# Altium schematic sheet Power_Supply.SchDoc
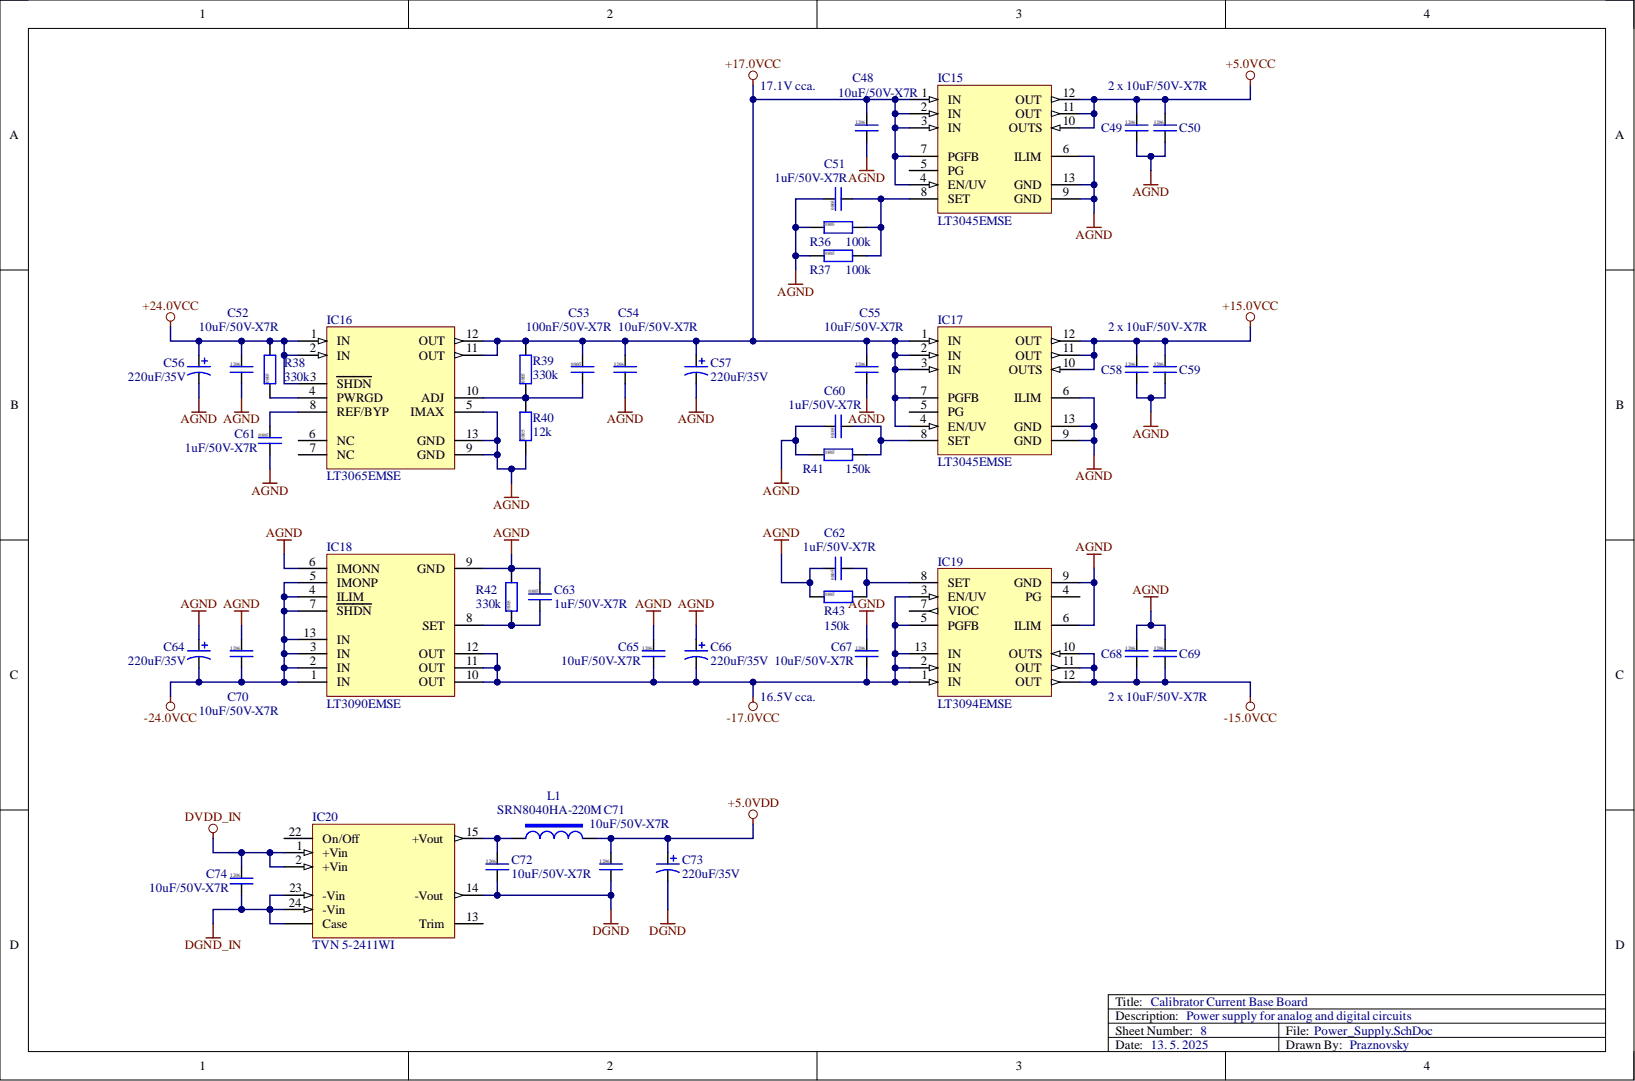
[diagram p0: Power_Supply.SchDoc]

## Parts
C48  10uF/50V-X7R  [C1206]
C49  10uF/50V-X7R  [C1206]
C50  10uF/50V-X7R  [C1206]
C51  1uF/50V-X7R  [C0805]
C52  10uF/50V-X7R  [C1206]
C53  100nF/50V-X7R  [C0805]
C54  10uF/50V-X7R  [C1206]
C55  10uF/50V-X7R  [C1206]
C56  220uF/35V  [ELCO 8.0mm x 10.2mm]
C57  220uF/35V  [ELCO 8.0mm x 10.2mm]
C58  10uF/50V-X7R  [C1206]
C59  10uF/50V-X7R  [C1206]
C60  1uF/50V-X7R  [C0805]
C61  1uF/50V-X7R  [C0805]
C62  1uF/50V-X7R  [C0805]
C63  1uF/50V-X7R  [C0805]
C64  220uF/35V  [ELCO 8.0mm x 10.2mm]
C65  10uF/50V-X7R  [C1206]
C66  220uF/35V  [ELCO 8.0mm x 10.2mm]
C67  10uF/50V-X7R  [C1206]
C68  10uF/50V-X7R  [C1206]
C69  10uF/50V-X7R  [C1206]
C70  10uF/50V-X7R  [C1206]
C71  10uF/50V-X7R  [C1206]
C72  10uF/50V-X7R  [C1206]
C73  220uF/35V  [ELCO 8.0mm x 10.2mm]
C74  10uF/50V-X7R  [C1206]
IC15  LT3045EMSE  [MSOP-12-EP]
IC16  LT3065EMSE  [MSOP-12-EP]
IC17  LT3045EMSE  [MSOP-12-EP]
IC18  LT3090EMSE  [MSOP-12-EP]
IC19  LT3094EMSE  [MSOP-12-EP]
IC20  TVN 5-2411WI  [TVN 5WI]
L1  SRN8040HA-220M  [SRN8040]
R36  100k  [R0805]
R37  100k  [R0805]
R38  330k  [R0805]
R39  330k  [R0805]
R40  12k  [R0805]
R41  150k  [R0805]
R42  330k  [R0805]
R43  150k  [R0805]

## Nets
+5.0VCC: C49.1 C50.1 IC15.10(OUTS) IC15.11(OUT) IC15.12(OUT)
+5.0VDD: C71.1 C73.1 L1.2
+15.0VCC: C58.1 C59.1 IC17.10(OUTS) IC17.11(OUT) IC17.12(OUT)
+17.0VCC: C48.1 C53.1 C54.1 C55.1 C57.1 IC15.1(IN) IC15.2(IN) IC15.3(IN) IC15.4(EN/UV) IC15.7(PGFB) IC16.11(OUT) IC16.12(OUT) IC17.1(IN) IC17.2(IN) IC17.3(IN) IC17.4(EN/UV) IC17.7(PGFB) R39.2
+24.0VCC: C52.1 C56.1 IC16.1(IN) IC16.2(IN) IC16.3(SHDN) R38.2
-15.0VCC: C68.2 C69.2 IC19.10(OUTS) IC19.11(OUT) IC19.12(OUT)
-17.0VCC: C65.2 C66.2 C67.2 IC18.10(OUT) IC18.11(OUT) IC18.12(OUT) IC19.1(IN) IC19.2(IN) IC19.3(EN/UV) IC19.5(PGFB) IC19.13(IN)
-24.0VCC: C64.2 C70.2 IC18.1(IN) IC18.2(IN) IC18.3(IN) IC18.4(ILIM) IC18.5(IMONP) IC18.7(SHDN) IC18.13(IN)
AGND: C48.2 C49.2 C50.2 C51.1 C52.2 C54.2 C55.2 C56.2 C57.2 C58.2 C59.2 C60.1 C61.2 C62.1 C63.1 C64.1 C65.1 C66.1 C67.1 C68.1 C69.1 C70.1 IC15.6(ILIM) IC15.9(GND) IC15.13(GND) IC16.5(IMAX) IC16.9(GND) IC16.13(GND) IC17.6(ILIM) IC17.9(GND) IC17.13(GND) IC18.6(IMONN) IC18.9(GND) IC19.6(ILIM) IC19.9(GND) R36.1 R37.1 R40.1 R41.1 R42.2 R43.1
DGND: C71.2 C72.2 C73.2 IC20.14(-Vout)
DGND_IN: C74.2 IC20.3, 12(Case) IC20.23(-Vin) IC20.24(-Vin)
DVDD_IN: C74.1 IC20.1(+Vin) IC20.2(+Vin)
NetC51_2: C51.2 IC15.8(SET) R36.2 R37.2
NetC53_2: C53.2 IC16.10(ADJ) R39.1 R40.2
NetC60_2: C60.2 IC17.8(SET) R41.2
NetC61_1: C61.1 IC16.8(REF/BYP)
NetC62_2: C62.2 IC19.8(SET) R43.2
NetC63_2: C63.2 IC18.8(SET) R42.1
NetC72_1: C72.1 IC20.15(+Vout) L1.1
NetIC15_5: IC15.5(PG)
NetIC16_4: IC16.4(PWRGD) R38.1
NetIC16_6: IC16.6(NC)
NetIC16_7: IC16.7(NC)
NetIC17_5: IC17.5(PG)
NetIC19_4: IC19.4(PG)
NetIC19_7: IC19.7(VIOC)
NetIC20_13: IC20.13(Trim)
NetIC20_22: IC20.22(On/Off)

## Library data of the parts
C48-C50 C52 C54 C55 C58 C59 C65 C67-C72 C74 = CMP-00068-2: Capacitor 1206; Component Kind: Standard; Library Name: Calibrator
C51 C53 C60-C63 = CMP-00067-2: Capacitor 0805; Component Kind: Standard; Library Name: Calibrator
C56 C57 C64 C66 C73 = CMP-00055-17: Electrolytic Capacitor; Component Kind: Standard; Library Name: Calibrator
IC15 IC17 = CMP-00002-21: 20V, 500mA, Ultralow Noise, Ultrahigh PSRR Linear Regulator; Component Kind: Standard; Library Name: Calibrator
IC16 = CMP-00049-7: 45V VIN, 500mA Low Noise, Linear Regulator with Programmable Current Limit and Power Good; Component Kind: Standard; Library Name: Calibrator
IC18 = CMP-00050-9: –36V, 600mA Negative Linear Regulator with Programmable Current Limit; Component Kind: Standard; Library Name: Calibrator
IC19 = CMP-00029-6: –20V, 500mA, Ultralow Noise, Ultrahigh PSRR Negative Linear Regulator; Component Kind: Standard; Library Name: Calibrator
IC20 = CMP-00073-2: DC/DC Converter TVN 5WI Series, 5 Watt; Component Kind: Standard; Library Name: Calibrator
L1 = CMP-00057-4: Power Inductors - SMD Ind,7.9x8.15x4.2mm,22uH+/-20%,2.2A,shd; Component Kind: Standard; Library Name: Calibrator
R36-R43 = CMP-00063-2: Resistor 0805; Component Kind: Standard; Library Name: Calibrator
